# Altium schematic sheet I2C.SchDoc(I2C)
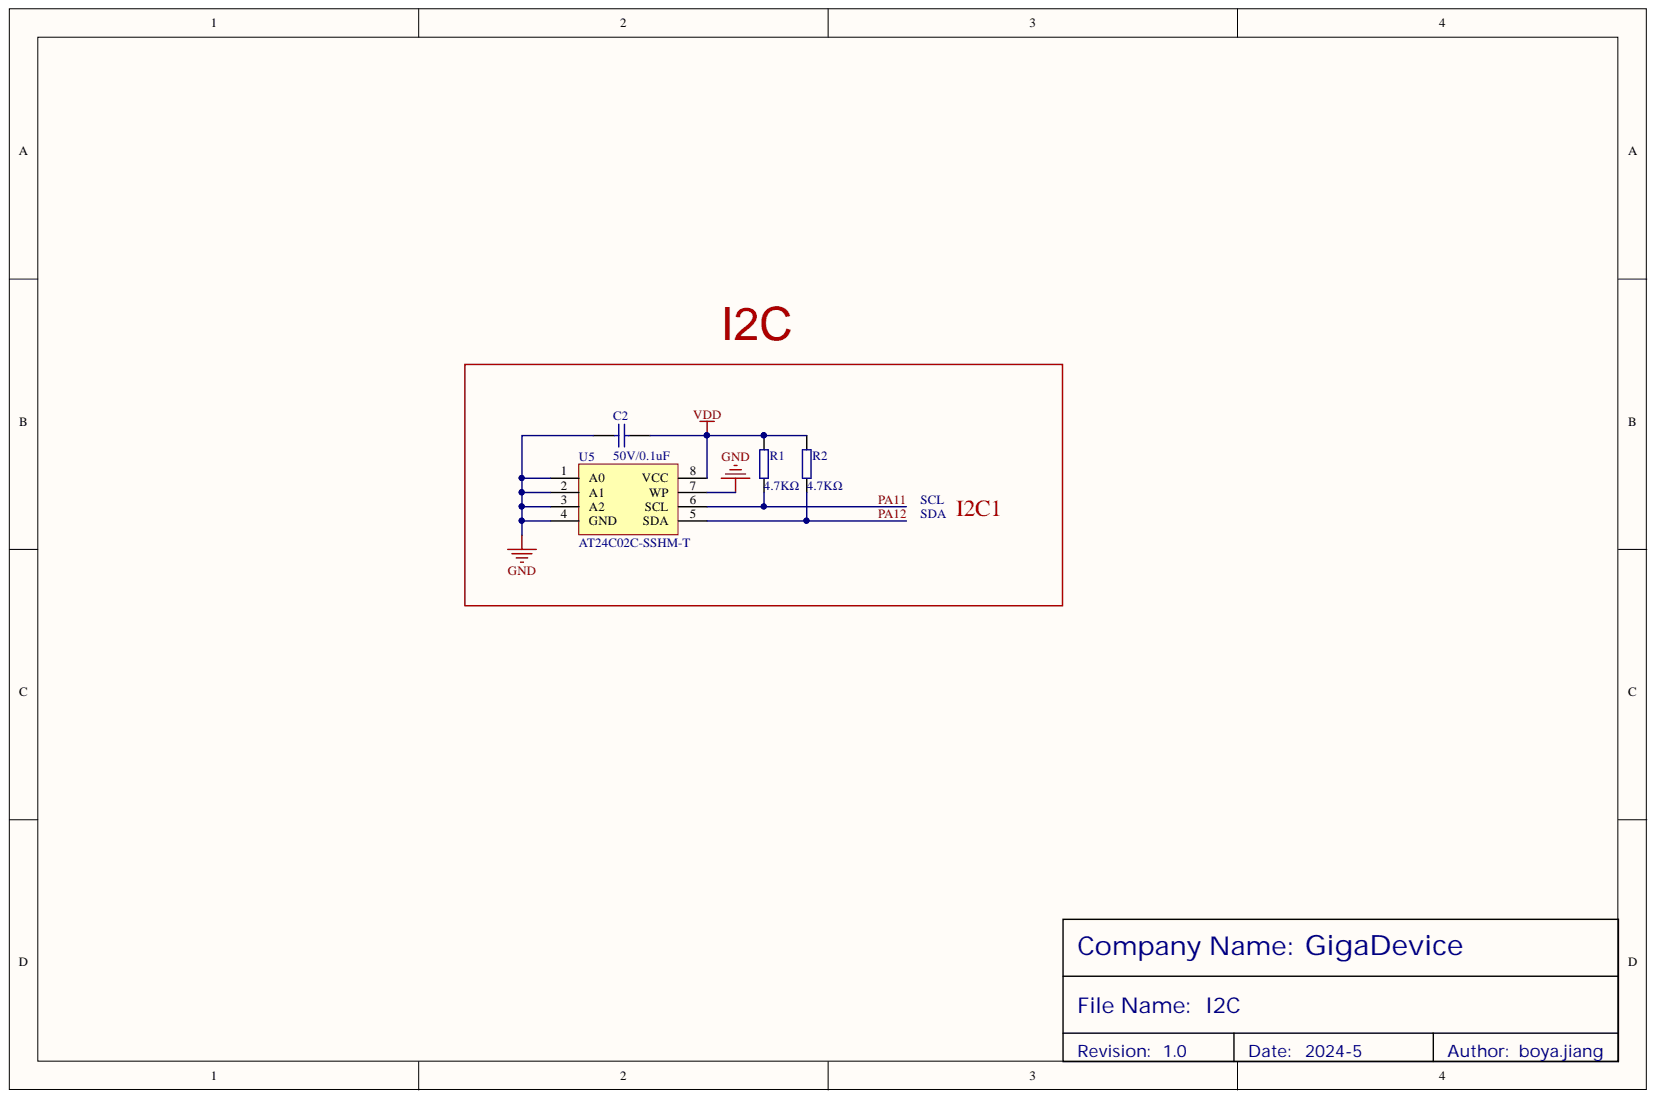
[diagram p0: I2C.SchDoc]

## Parts
C2  50V/0.1uF  [0603-C]
R1  4.7KΩ  [0603-R]
R2  4.7KΩ  [0603-R]
U5  AT24C02C-SSHM-T  [SOIC-8]

## Nets
GND: C2.1 U5.1(A0) U5.2(A1) U5.3(A2) U5.4(GND) U5.7(WP)
VDD: C2.2 R1.2 R2.2 U5.8(VCC)
PA11: R1.1 U5.6(SCL)
PA12: R2.1 U5.5(SDA)

## Library data of the parts
C2 = 50V/0.1uF: 表贴电容; Component Kind: Standard; Library Name: KLTC-电容.SchLib
R1 R2 = 4.7KΩ: 表贴电阻; Component Kind: Standard; Library Name: KLTC-电阻.SchLib
U5 = AT24C02C-SSHM-T: 表贴I2C EEPROM芯片; Component Kind: Standard; Library Name: KLTC-芯片类.SchLib
